# Altium schematic sheet Sheet2.SchDoc
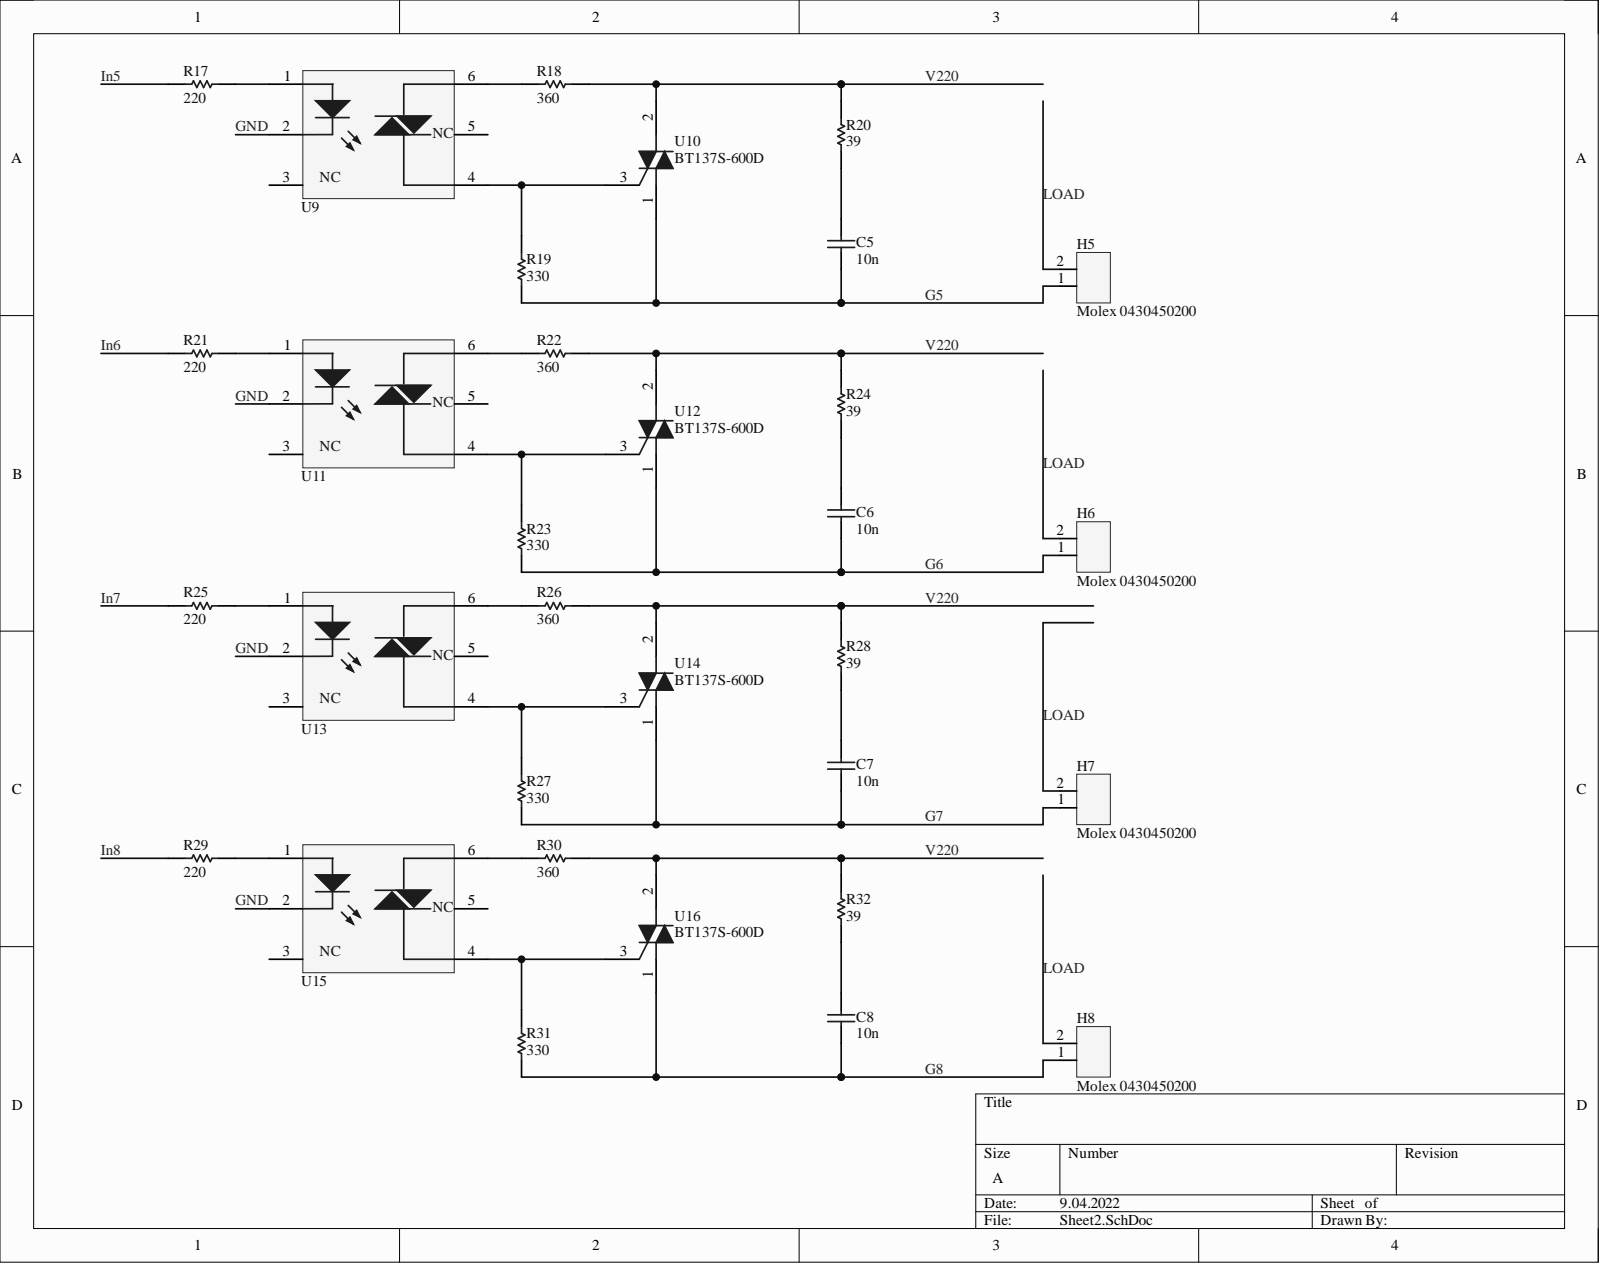
[diagram p0: Sheet2.SchDoc]

## Parts
C5  10n  [PCB-vcjr8nxpwfuh09uwlcd6-1]
C6  10n  [PCB-vcjr8nxpwfuh09uwlcd6-1]
C7  10n  [PCB-vcjr8nxpwfuh09uwlcd6-1]
C8  10n  [PCB-vcjr8nxpwfuh09uwlcd6-1]
H5  Molex 0430450200  Manufacturer Part Number: 0430450200  [PCB-lpc9z9t8uewl5xeystzy-1]
H6  Molex 0430450200  Manufacturer Part Number: 0430450200  [PCB-lpc9z9t8uewl5xeystzy-1]
H7  Molex 0430450200  Manufacturer Part Number: 0430450200  [PCB-lpc9z9t8uewl5xeystzy-1]
H8  Molex 0430450200  Manufacturer Part Number: 0430450200  [PCB-lpc9z9t8uewl5xeystzy-1]
R17  220  [RESC2013X50X30ML20T20]
R18  360  [RESC2013X50X30ML20T20]
R19  330  [RESC2013X50X30ML20T20]
R20  39  [RESC2013X50X30ML20T20]
R21  220  [RESC2013X50X30ML20T20]
R22  360  [RESC2013X50X30ML20T20]
R23  330  [RESC2013X50X30ML20T20]
R24  39  [RESC2013X50X30ML20T20]
R25  220  [RESC2013X50X30ML20T20]
R26  360  [RESC2013X50X30ML20T20]
R27  330  [RESC2013X50X30ML20T20]
R28  39  [RESC2013X50X30ML20T20]
R29  220  [RESC2013X50X30ML20T20]
R30  360  [RESC2013X50X30ML20T20]
R31  330  [RESC2013X50X30ML20T20]
R32  39  [RESC2013X50X30ML20T20]
U9  MOC3043  [PCB-723iinp4b8wdj569zabb-1]  (value not drawn)
U10  BT137S-600D  [PCB-lydts9a3iyc23ocvkdlb-1]
U11  MOC3043  [PCB-723iinp4b8wdj569zabb-1]  (value not drawn)
U12  BT137S-600D  [PCB-lydts9a3iyc23ocvkdlb-1]
U13  MOC3043  [PCB-723iinp4b8wdj569zabb-1]  (value not drawn)
U14  BT137S-600D  [PCB-lydts9a3iyc23ocvkdlb-1]
U15  MOC3043  [PCB-723iinp4b8wdj569zabb-1]  (value not drawn)
U16  BT137S-600D  [PCB-lydts9a3iyc23ocvkdlb-1]

## Nets
G5: C5.1 H5.1 R19.1 U10.1
G6: C6.1 H6.1 R23.1 U12.1
G7: C7.1 H7.1 R27.1 U14.1
G8: C8.1 H8.1 R31.1 U16.1
GND: U9.2 U11.2 U13.2 U15.2
In5: R17.1
In6: R21.1
In7: R25.1
In8: R29.1
LOAD: H5.2 H6.2 H7.2 H8.2
V220: R18.2 R20.2 R22.2 R24.2 R26.2 R28.2 R30.2 R32.2 U10.2 U12.2 U14.2 U16.2
NetC5_2: C5.2 R20.1
NetC6_2: C6.2 R24.1
NetC7_2: C7.2 R28.1
NetC8_2: C8.2 R32.1
NetR17_2: R17.2 U9.1
NetR18_1: R18.1 U9.6
NetR19_2: R19.2 U9.4 U10.3
NetR21_2: R21.2 U11.1
NetR22_1: R22.1 U11.6
NetR23_2: R23.2 U11.4 U12.3
NetR25_2: R25.2 U13.1
NetR26_1: R26.1 U13.6
NetR27_2: R27.2 U13.4 U14.3
NetR29_2: R29.2 U15.1
NetR30_1: R30.1 U15.6
NetR31_2: R31.2 U15.4 U16.3

Not connected: U9 3(NC) 5(NC); U11 3 5(NC); U13 3 5(NC); U15 3 5(NC)

## Library data of the parts
C5-C8 = CMP-526e9b1a13078da9-2: CL21 Series 10 nF 50V ±10 % Tolerance X7R SMT Multilayer Ceramic Capacitor; Manufacturer Part Number: CL21B103KBANNNC; Capacitance: 1e-08 F; Capacitance Tolerance: ±10%; Lead-Free Status: Lead Free; Voltage Rating (DC): 50.0 V; Case/Package: 0805; Component Kind: Standard; Dielectric Characteristic: X7R; Library Name: CommunityVault; Mounting Style: Surface Mount; Octopart URL: http://octopart.com/cl21b103kbannnc-samsung-8283151; OctopartID: 526e9b1a13078da9; RoHS: Compliant
H5-H8 = CMP-b9e170746c1a6626-2: Conn Wire to Board HDR 2 POS Solder RA Thru-Hole Micro-Fit 3.0Ã¢âÂ¢ Tray; Component Kind: Standard; Contact Plating: Tin; Flammability Rating: UL 94 V-0; Library Name: CommunityVault; Lifecycle Status: Not Listed by Manufacturer; Octopart URL: http://octopart.com/0430450200-molex-10481821; OctopartID: b9e170746c1a6626; Orientation: Right Angle; RoHS: Compliant
R17-R32 = CMP-1857726-2: Res Thick Film 0805 100K Ohm 1% 1/8W ±100ppm/C Molded SMD Paper T/R; Case/Package (SI): 2012; Lead-Free Status: Lead Free; Power Rating: 0.125 W; Resistance: 100000.0 Ω; Temperature Coefficient: 100.0 ppm/°C; Voltage Rating (DC): 150.0 V; Case/Package: 0805; Component Kind: Standard; Library Name: CommunityVault; Mounting Style: Surface Mount; Number of Pins: 2; Octopart Url: https://octopart.com/crcw0805100kfkea-vishay-39456141; OctopartID: 6231a9614adabdf6; REACH SVHC Compliance: No SVHC; Resistance Tolerance: ±1%; RoHS: Compliant; Size-Height: 0.00045 m; Size-Length: 0.002 m; Size-Width: 0.00125 m
U9 U11 U13 U15 = CMP-2a1be31bc7c9f5dc-2: OPTOCOUPLER, TRANSISTOR O/P; No. of Channels: 1; Voltage, Isolation: 5300V; Output Type: Transistor; Input Current: 6...; Manufacturer Part Number: 4N25SR2VM; Lead-Free Status: Lead Free; Number of Channels: 1; Output Voltage: V; Rise Time: 2e-06 s; Input Current: 0.01 A; Input Voltage (DC): 1.18 V; Isolation Voltage: 5300.0 V; Component Kind: Standard; Library Name: CommunityVault; Lifecycle Status: Active; Mounting Style: Surface Mount; Number of Circuits: 1; Number of Pins: 6; Octopart URL: http://octopart.com/4n25sr2vm-fairchild+semiconductor-706740; OctopartID: 2a1be31bc7c9f5dc; Packaging: Tape & Reel (TR); REACH SVHC Compliance: No SVHC; RoHS: Compliant
U10 U12 U14 U16 = CMP-a42a6dbf3fa07bed-1: Thyristor TRIAC 600V 71A 3-Pin(2+Tab) DPAK T/R; Manufacturer Part Number: BT137S-600D,118; Lead-Free Status: Lead Free; Component Kind: Standard; Library Name: CommunityVault; Lifecycle Status: Active; Mounting Style: Surface Mount; Number of Pins: 3; Octopart URL: http://octopart.com/bt137s-600d%2C118-nxp+semiconductors-10469100; OctopartID: a42a6dbf3fa07bed; Packaging: Cut Tape (CT); REACH SVHC Compliance: No SVHC; RoHS: Compliant
